# Altium schematic sheet P07_STM32MP1_DDR3_XTAL_RT.SchDoc(P07_STM32MP1_DDR3_XTAL_RT)
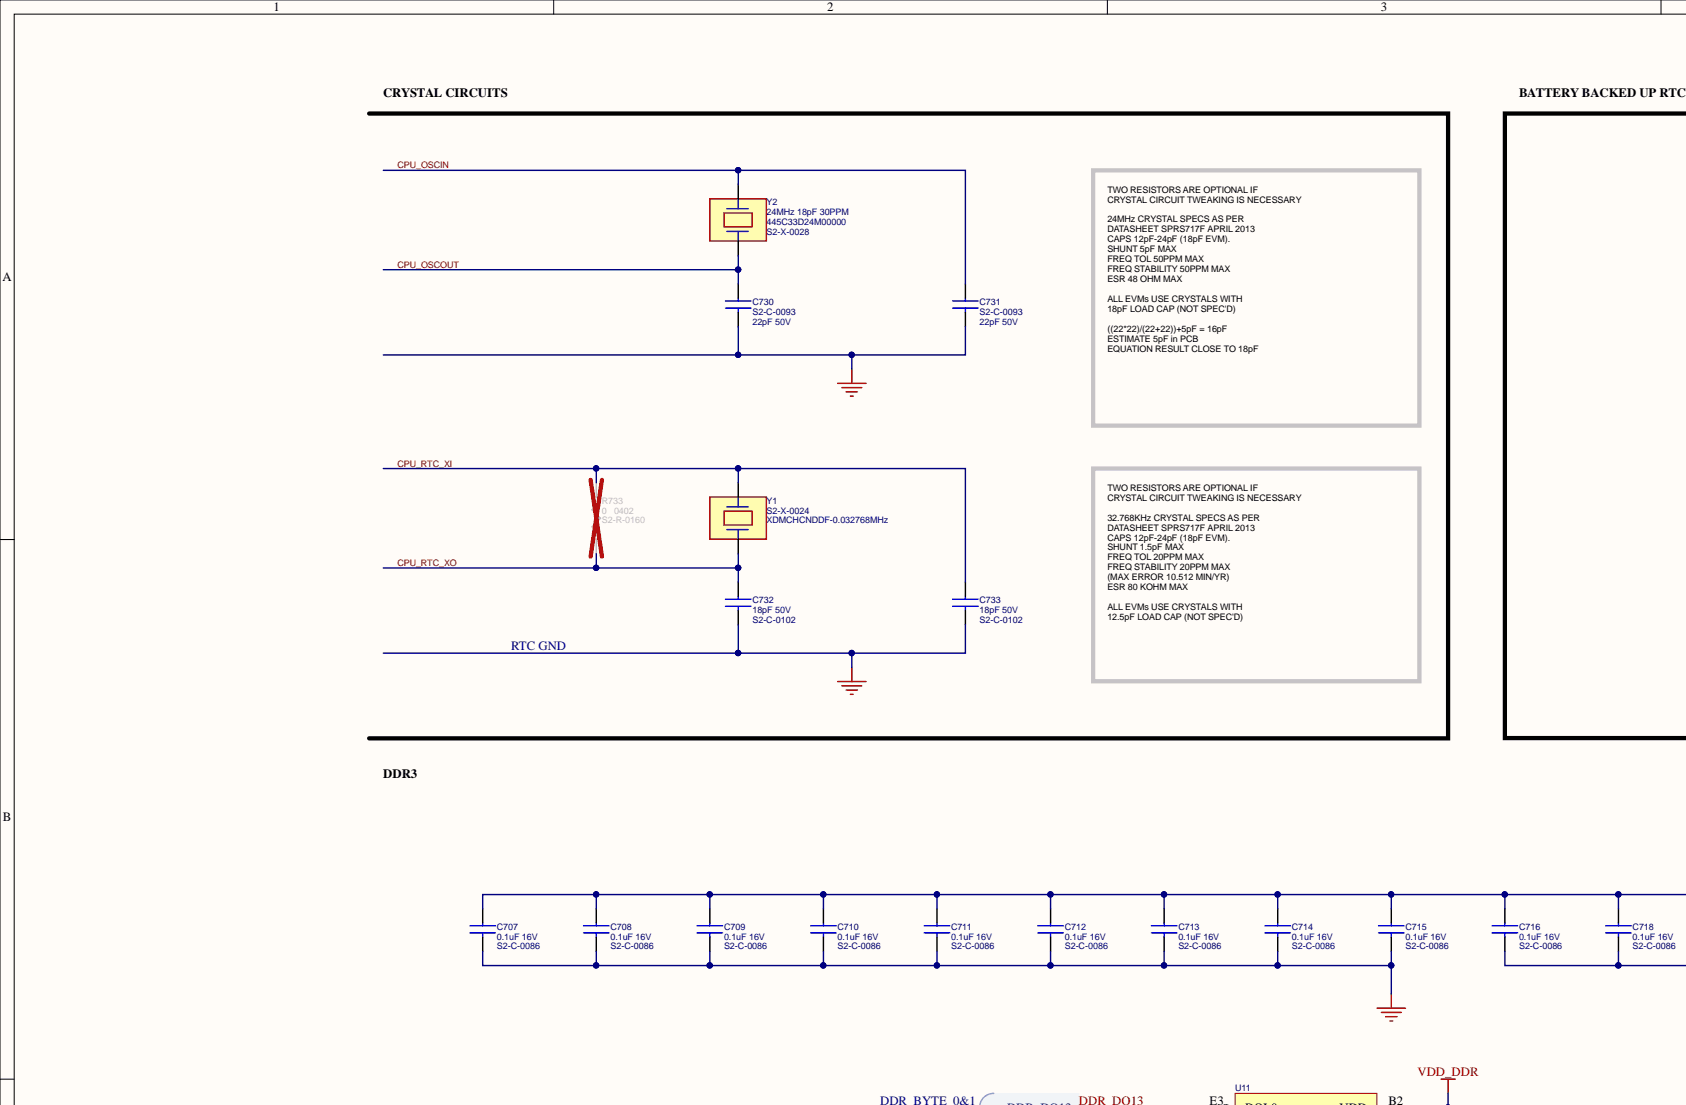
[diagram p0: P07_STM32MP1_DDR3_XTAL_RT.SchDoc, top left]
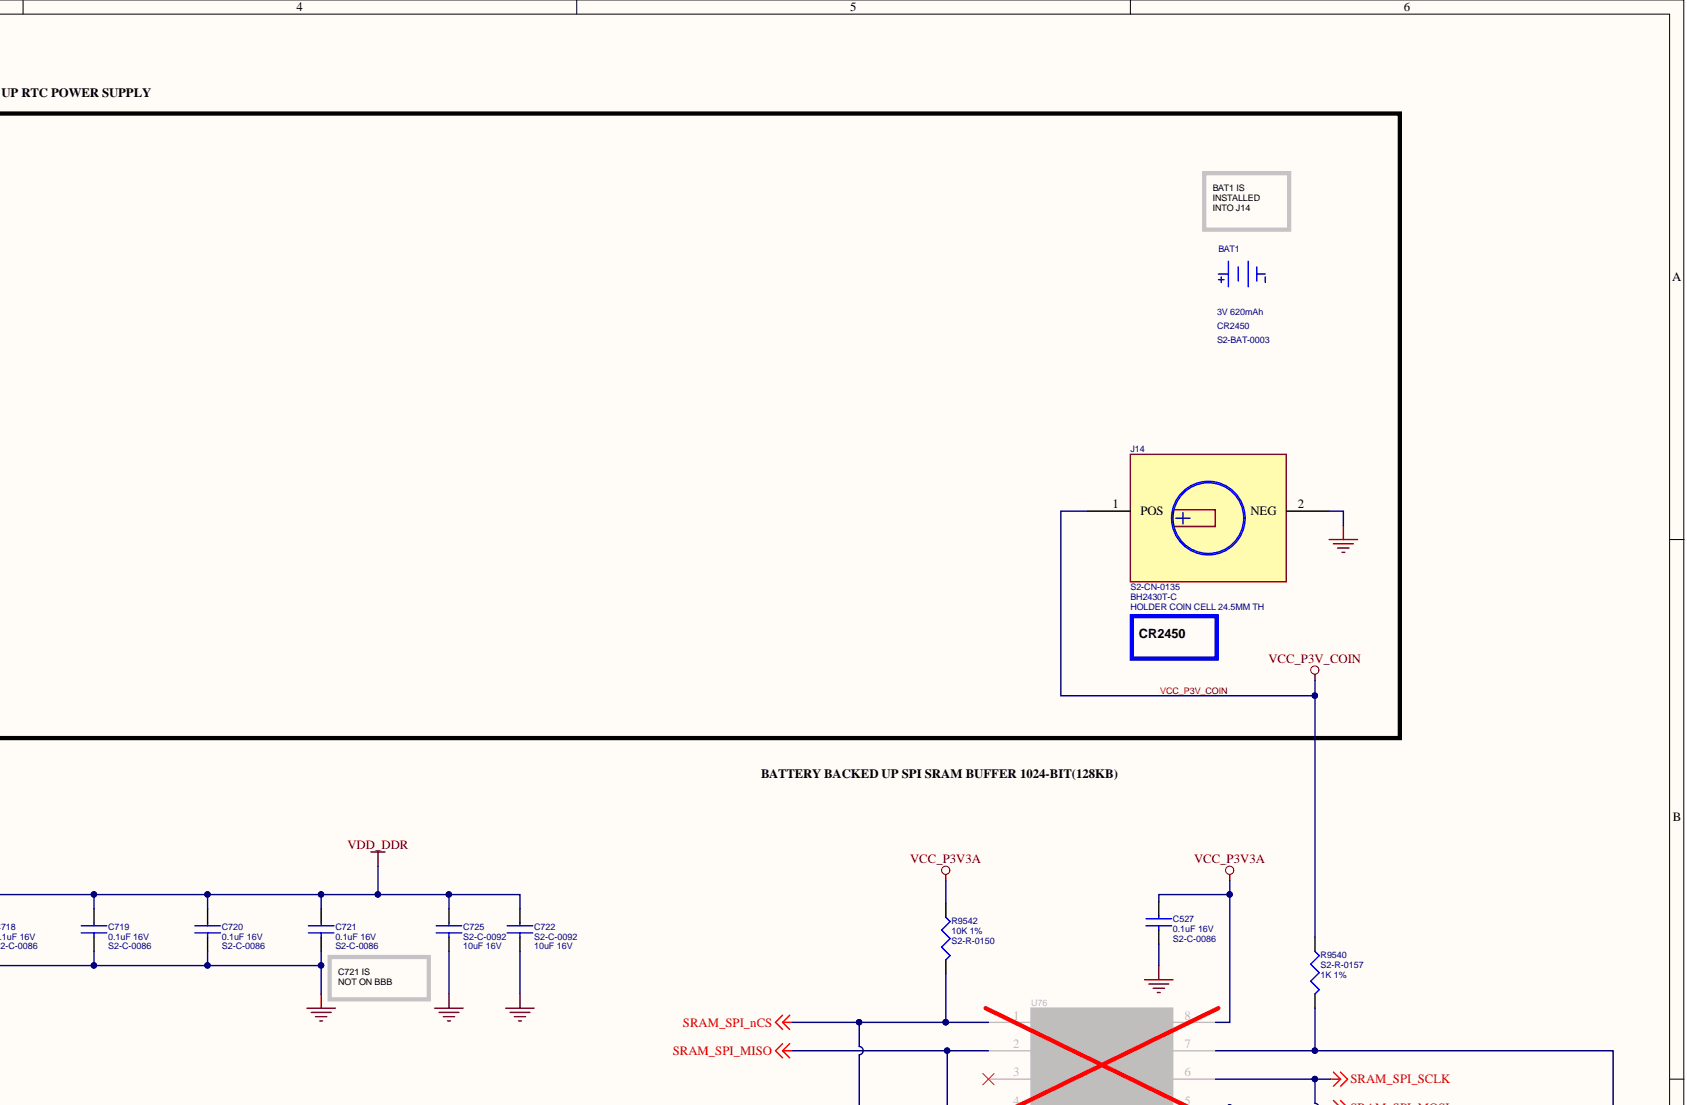
[diagram p1: P07_STM32MP1_DDR3_XTAL_RT.SchDoc, top right]
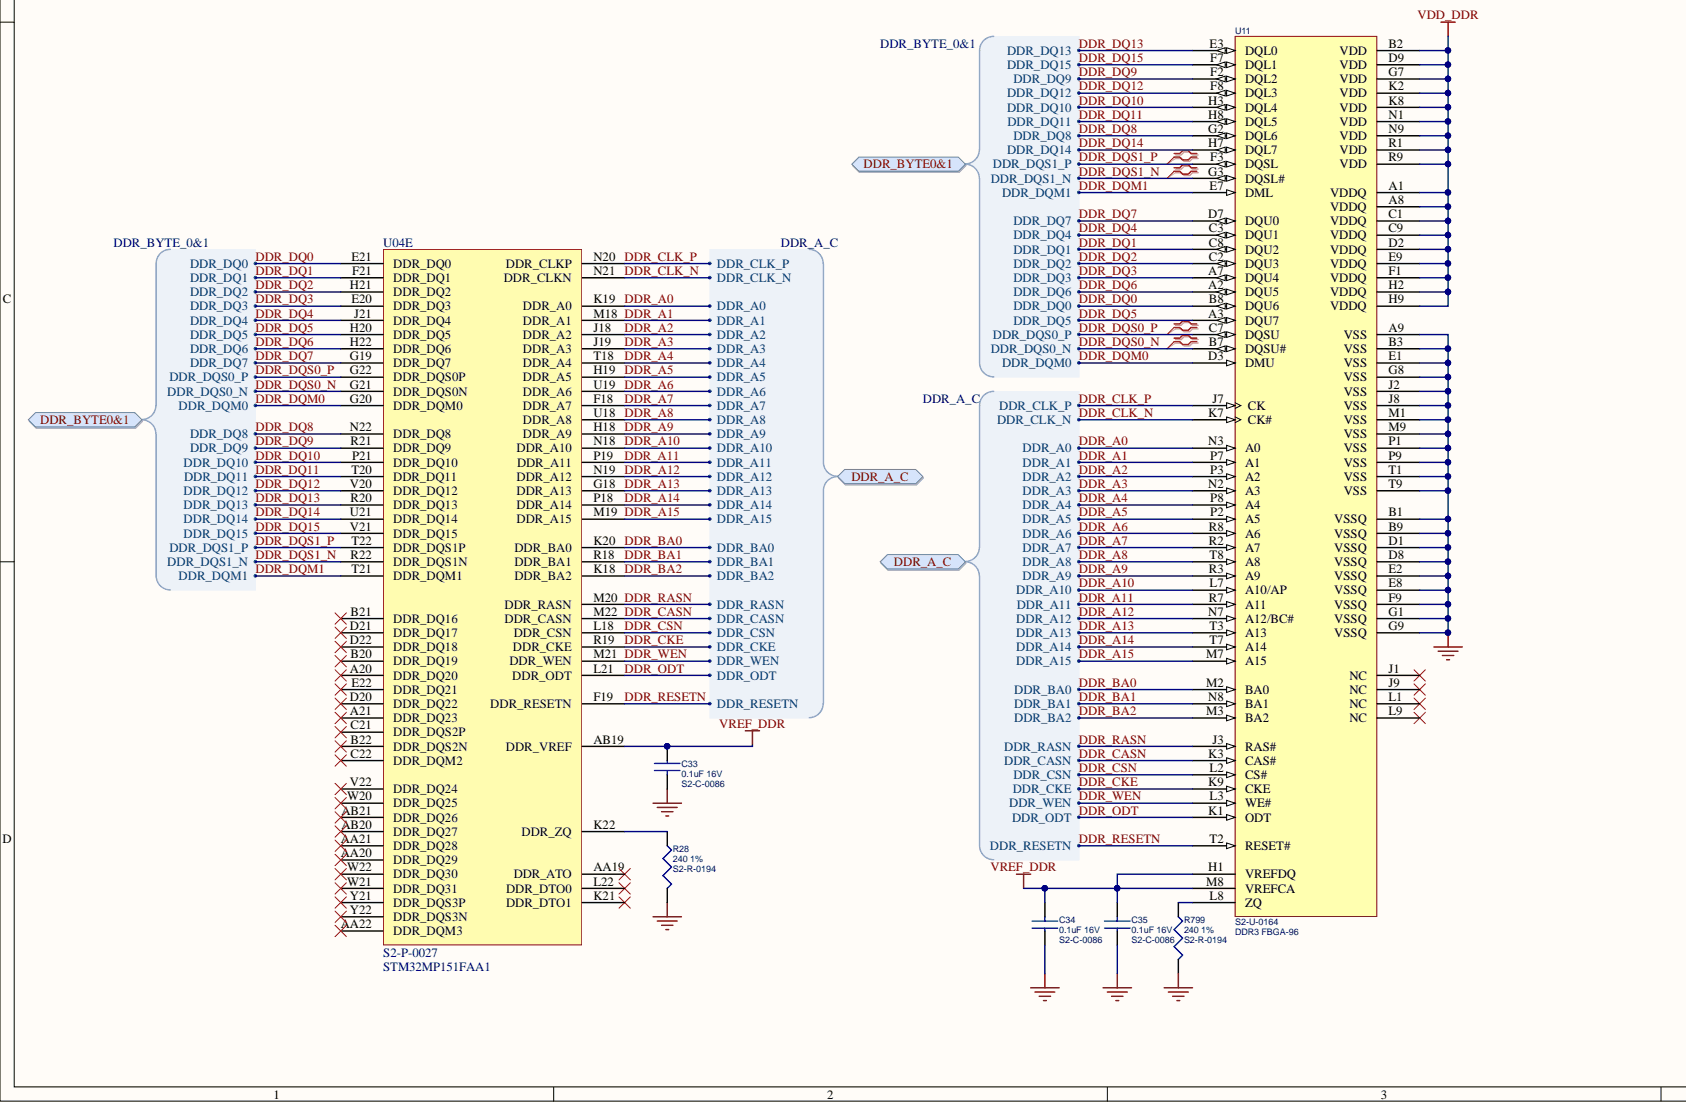
[diagram p2: P07_STM32MP1_DDR3_XTAL_RT.SchDoc, bottom left]
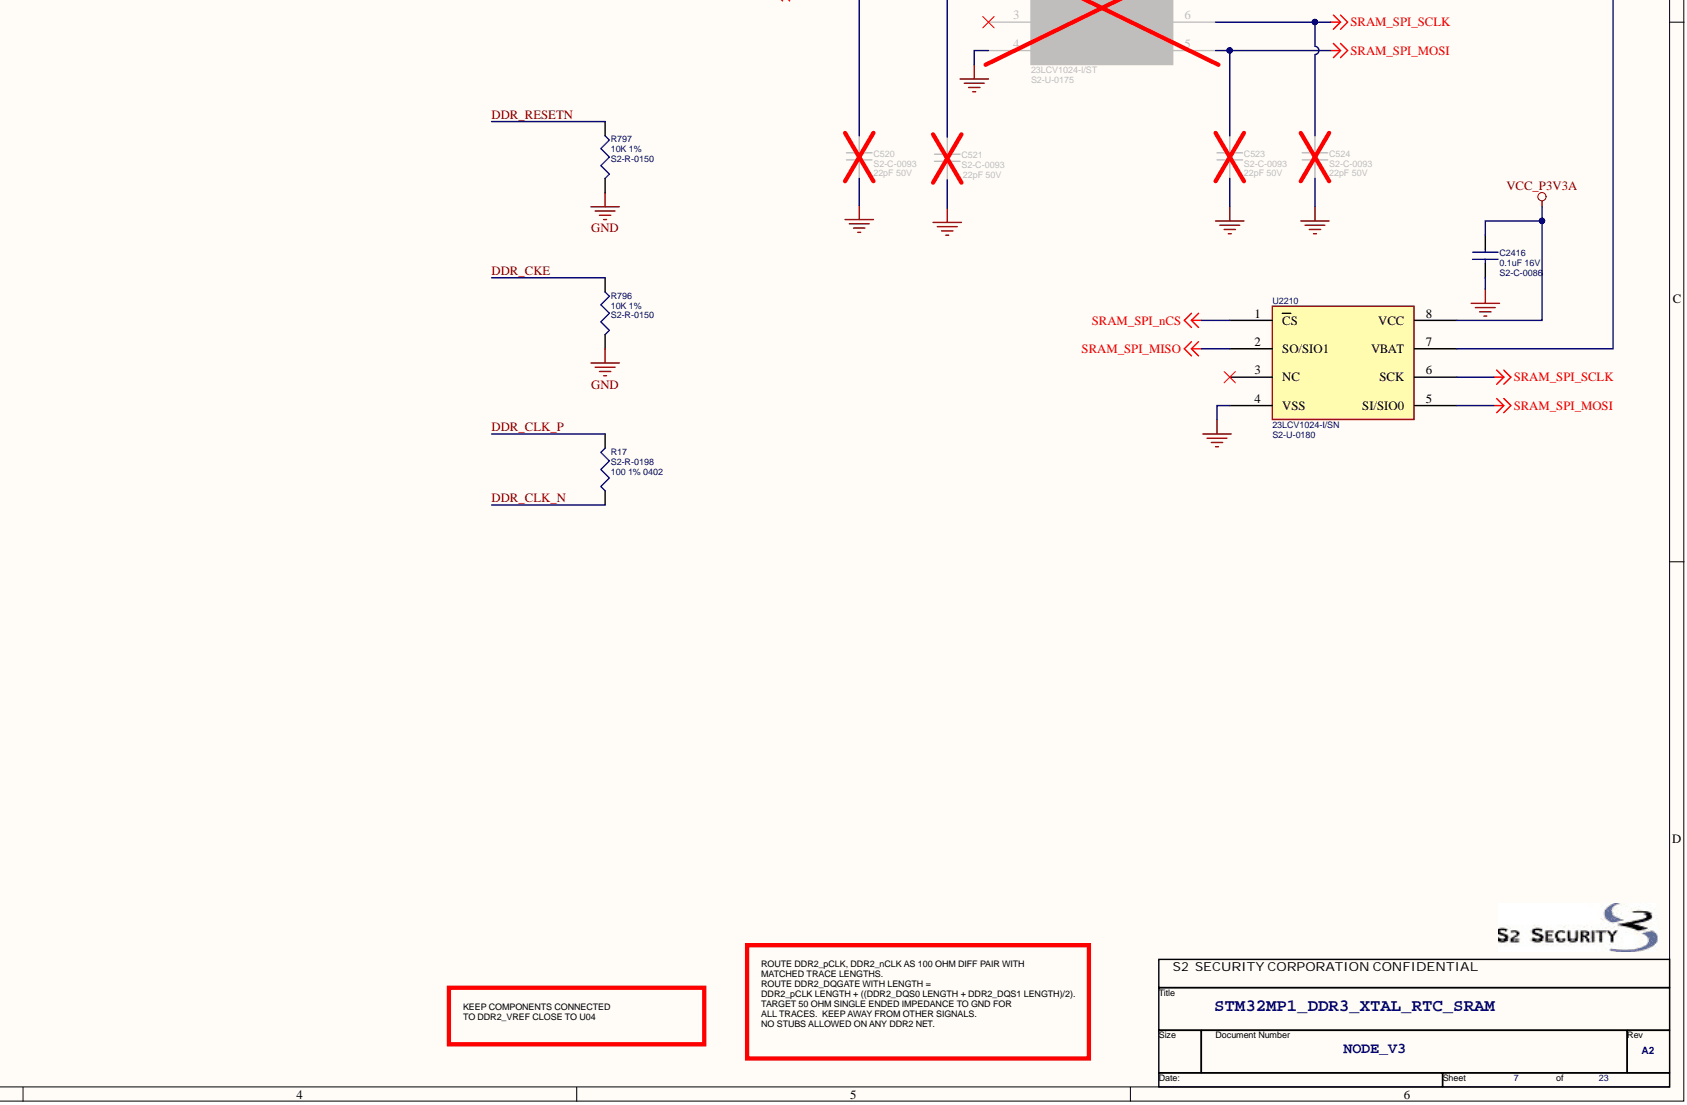
[diagram p3: P07_STM32MP1_DDR3_XTAL_RT.SchDoc, bottom right]

## Parts
BAT1  S2_PN: S2-BAT-0003  DESC: CR2450  ATTR: 3V 620mAh  [N/A]  (hidden comment: BAT_CR2450)
C33  S2_PN: S2-C-0086  DESC: 0.1uF 16V  [CAPC1005X55N]  (hidden comment: C_0U1_16V_0402)
C34  S2_PN: S2-C-0086  [CAPC1005X55N]  (hidden comment: C_0U1_16V_0402)
C35  S2_PN: S2-C-0086  DESC: 0.1uF 16V  [CAPC1005X55N]  (hidden comment: C_0U1_16V_0402)
C520  S2_PN: S2-C-0093  DESC: 22pF 50V  [CAPC1005X55N]  (hidden comment: C_22P_50V_0402_C0G)
C521  S2_PN: S2-C-0093  DESC: 22pF 50V  [CAPC1005X55N]  (hidden comment: C_22P_50V_0402_C0G)
C523  S2_PN: S2-C-0093  DESC: 22pF 50V  [CAPC1005X55N]  (hidden comment: C_22P_50V_0402_C0G)
C524  S2_PN: S2-C-0093  DESC: 22pF 50V  [CAPC1005X55N]  (hidden comment: C_22P_50V_0402_C0G)
C527  S2_PN: S2-C-0086  DESC: 0.1uF 16V  [CAPC1005X55N]  (hidden comment: C_0U1_16V_0402)
C707  S2_PN: S2-C-0086  DESC: 0.1uF 16V  [CAPC1005X55N]  (hidden comment: C_0U1_16V_0402)
C708  S2_PN: S2-C-0086  DESC: 0.1uF 16V  [CAPC1005X55N]  (hidden comment: C_0U1_16V_0402)
C709  S2_PN: S2-C-0086  DESC: 0.1uF 16V  [CAPC1005X55N]  (hidden comment: C_0U1_16V_0402)
C710  S2_PN: S2-C-0086  DESC: 0.1uF 16V  [CAPC1005X55N]  (hidden comment: C_0U1_16V_0402)
C711  S2_PN: S2-C-0086  DESC: 0.1uF 16V  [CAPC1005X55N]  (hidden comment: C_0U1_16V_0402)
C712  S2_PN: S2-C-0086  DESC: 0.1uF 16V  [CAPC1005X55N]  (hidden comment: C_0U1_16V_0402)
C713  S2_PN: S2-C-0086  DESC: 0.1uF 16V  [CAPC1005X55N]  (hidden comment: C_0U1_16V_0402)
C714  S2_PN: S2-C-0086  DESC: 0.1uF 16V  [CAPC1005X55N]  (hidden comment: C_0U1_16V_0402)
C715  S2_PN: S2-C-0086  DESC: 0.1uF 16V  [CAPC1005X55N]  (hidden comment: C_0U1_16V_0402)
C716  S2_PN: S2-C-0086  DESC: 0.1uF 16V  [CAPC1005X55N]  (hidden comment: C_0U1_16V_0402)
C718  S2_PN: S2-C-0086  DESC: 0.1uF 16V  [CAPC1005X55N]  (hidden comment: C_0U1_16V_0402)
C719  S2_PN: S2-C-0086  DESC: 0.1uF 16V  [CAPC1005X55N]  (hidden comment: C_0U1_16V_0402)
C720  S2_PN: S2-C-0086  DESC: 0.1uF 16V  [CAPC1005X55N]  (hidden comment: C_0U1_16V_0402)
C721  S2_PN: S2-C-0086  DESC: 0.1uF 16V  [CAPC1005X55N]  (hidden comment: C_0U1_16V_0402)
C722  S2_PN: S2-C-0092  DESC: 10uF 16V  [CAPC2012X145N]  (hidden comment: C_10U_16V_0805)
C725  S2_PN: S2-C-0092  [CAPC2012X145N]  (hidden comment: C_10U_16V_0805)
C730  S2_PN: S2-C-0093  DESC: 22pF 50V  [CAPC1005X55N]  (hidden comment: C_22P_50V_0402_C0G)
C731  S2_PN: S2-C-0093  DESC: 22pF 50V  [CAPC1005X55N]  (hidden comment: C_22P_50V_0402_C0G)
C732  S2_PN: S2-C-0102  DESC: 18pF 50V  [CAPC1005X55N]  (hidden comment: C_18P_50V_0402_C0G)
C733  S2_PN: S2-C-0102  DESC: 18pF 50V  [CAPC1005X55N]  (hidden comment: C_18P_50V_0402_C0G)
C2416  S2_PN: S2-C-0086  DESC: 0.1uF 16V  [CAPC1005X55N]  (hidden comment: C_0U1_16V_0402)
J14  S2_PN: S2-CN-0135  DESC: BH2430T-C  ATTR: HOLDER COIN CELL 24.5MM TH  [BAT_BH2430T-C]  (hidden comment: CN_BH2430T_FOR_CR2450__BH32T)
R17  S2_PN: S2-R-0198  DESC: 100 1% 0402  [RESC1005X40N]  (hidden comment: R_100_0402)
R28  S2_PN: S2-R-0194  DESC: 240 1%  [RESC1005X40N]  (hidden comment: R_240_0402)
R733  S2_PN: S2-R-0160  DESC: 0   0402  [RESC1005X40N]  (hidden comment: R_0_0402)
R796  S2_PN: S2-R-0150  DESC: 10K 1%  [RESC1005X40N]  (hidden comment: R_10K_0402)
R797  S2_PN: S2-R-0150  DESC: 10K 1%  [RESC1005X40N]  (hidden comment: R_10K_0402)
R799  S2_PN: S2-R-0194  DESC: 240 1%  [RESC1005X40N]  (hidden comment: R_240_0402)
R9540  S2_PN: S2-R-0157  DESC: 1K 1%  [RESC1005X40N]  (hidden comment: R_1K_0402)
R9542  S2_PN: S2-R-0150  DESC: 10K 1%  [RESC1005X40N]  (hidden comment: R_10K_0402)
U04  S2_PN: S2-P-0027  DESC: STM32MP151FAA1  [ICC_LFBGA448_18X18_STM32MP157BAA3_P080]  (hidden comment: U_STM32MP15xxAAx)  (part E)
U11  S2_PN: S2-U-0164  PKG: FBGA-96  DESC: DDR3 FBGA-96  [BGA96_9MMX13.5MM_.8MM]  (hidden comment: U_DDR3_FPGA-96)
U76  S2_PN: S2-U-0175  DESC: 23LCV1024-I/ST  [TSSOP-8]  (hidden comment: U_NVSRAM_SPI_TSSOP-8)
U2210  S2_PN: S2-U-0180  DESC: 23LCV1024-I/SN  [SOIC127P600X175-8N]  (hidden comment: U_NVSRAM_SPI_SOIC-8)
Y1  S2_PN: S2-X-0024  DESC: XDMCHCNDDF-0.032768MHz  [Crystal_XDMCHCNDDF]  (hidden comment: X_32M768_XTAL_XD)
Y2  S2_PN: S2-X-0028  DESC: 445C33D24M00000  [Crystal_445C33D24M00000]  (hidden comment: X_24MHZ_XTAL_2P_5X3MM2)

## Ports
DDR_A_C, DDR_A_C.DDR_A0, DDR_A_C.DDR_A1, DDR_A_C.DDR_A2, DDR_A_C.DDR_A3, DDR_A_C.DDR_A4, DDR_A_C.DDR_A5, DDR_A_C.DDR_A6, DDR_A_C.DDR_A7, DDR_A_C.DDR_A8, DDR_A_C.DDR_A9, DDR_A_C.DDR_A10, DDR_A_C.DDR_A11, DDR_A_C.DDR_A12, DDR_A_C.DDR_A13, DDR_A_C.DDR_A14, DDR_A_C.DDR_A15, DDR_A_C.DDR_BA0, DDR_A_C.DDR_BA1, DDR_A_C.DDR_BA2, DDR_A_C.DDR_CASN, DDR_A_C.DDR_CKE, DDR_A_C.DDR_CLK_N, DDR_A_C.DDR_CLK_P, DDR_A_C.DDR_CSN, DDR_A_C.DDR_ODT, DDR_A_C.DDR_RASN, DDR_A_C.DDR_RESETN, DDR_A_C.DDR_WEN, DDR_BYTE0&1, DDR_BYTE0&1.DDR_DQ0, DDR_BYTE0&1.DDR_DQ1, DDR_BYTE0&1.DDR_DQ2, DDR_BYTE0&1.DDR_DQ3, DDR_BYTE0&1.DDR_DQ4, DDR_BYTE0&1.DDR_DQ5, DDR_BYTE0&1.DDR_DQ6, DDR_BYTE0&1.DDR_DQ7, DDR_BYTE0&1.DDR_DQ8, DDR_BYTE0&1.DDR_DQ9, DDR_BYTE0&1.DDR_DQ10, DDR_BYTE0&1.DDR_DQ11, DDR_BYTE0&1.DDR_DQ12, DDR_BYTE0&1.DDR_DQ13, DDR_BYTE0&1.DDR_DQ14, DDR_BYTE0&1.DDR_DQ15, DDR_BYTE0&1.DDR_DQM0, DDR_BYTE0&1.DDR_DQM1, DDR_BYTE0&1.DDR_DQS0_N, DDR_BYTE0&1.DDR_DQS0_P, DDR_BYTE0&1.DDR_DQS1_N, DDR_BYTE0&1.DDR_DQS1_P

## Nets
GND: C33.2 C34.1 C35.1 C520.2 C521.2 C523.2 C524.2 C527.2 C707.2 C708.2 C709.2 C710.2 C711.2 C712.2 C713.2 C714.2 C715.2 C716.2 C718.2 C719.2 C720.2 C721.2 C722.2 C725.2 C730.2 C731.2 C732.2 C733.2 C2416.2 J14.2 R28.2 R796.2 R797.2 R799.2 U11.A9(VSS) U11.B1(VSSQ) U11.B3(VSS) U11.B9(VSSQ) U11.D1(VSSQ) U11.D8(VSSQ) U11.E1(VSS) U11.E2(VSSQ) U11.E8(VSSQ) U11.F9(VSSQ) U11.G1(VSSQ) U11.G8(VSS) U11.G9(VSSQ) U11.J2(VSS) U11.J8(VSS) U11.M1(VSS) U11.M9(VSS) U11.P1(VSS) U11.P9(VSS) U11.T1(VSS) U11.T9(VSS) U76.4(VSS) U2210.4(VSS)
SRAM_SPI_MISO: C521.1 U76.2(SO/SIO1) U2210.2(SO/SIO1)
SRAM_SPI_MOSI: C523.1 U76.5(SI/SIO0) U2210.5(SI/SIO0)
SRAM_SPI_nCS: C520.1 R9542.2 U76.1(CS) U2210.1(CS)
SRAM_SPI_SCLK: C524.1 U76.6(SCK) U2210.6(SCK)
VCC_P3V3A: C527.1 C2416.1 R9542.1 U76.8(VCC) U2210.8(VCC)
VDD_DDR: C707.1 C708.1 C709.1 C710.1 C711.1 C712.1 C713.1 C714.1 C715.1 C716.1 C718.1 C719.1 C720.1 C721.1 C722.1 C725.1 U11.A1(VDDQ) U11.A8(VDDQ) U11.B2(VDD) U11.C1(VDDQ) U11.C9(VDDQ) U11.D2(VDDQ) U11.D9(VDD) U11.E9(VDDQ) U11.F1(VDDQ) U11.G7(VDD) U11.H2(VDDQ) U11.H9(VDDQ) U11.K2(VDD) U11.K8(VDD) U11.N1(VDD) U11.N9(VDD) U11.R1(VDD) U11.R9(VDD)
VREF_DDR: C33.1 C34.2 C35.2 U04.AB19(DDR_VREF) U11.H1(VREFDQ) U11.M8(VREFCA)
CPU_OSCIN: C731.1 Y2.2
CPU_OSCOUT: C730.1 Y2.1
CPU_RTC_XI: C733.1 R733.1 Y1.2
CPU_RTC_XO: C732.1 R733.2 Y1.1
DDR_A0: U04.K19(DDR_A0) U11.N3(A0) ; port DDR_A_C
DDR_A1: U04.M18(DDR_A1) U11.P7(A1) ; port DDR_A_C
DDR_A2: U04.J18(DDR_A2) U11.P3(A2) ; port DDR_A_C
DDR_A3: U04.J19(DDR_A3) U11.N2(A3) ; port DDR_A_C
DDR_A4: U04.T18(DDR_A4) U11.P8(A4) ; port DDR_A_C
DDR_A5: U04.H19(DDR_A5) U11.P2(A5) ; port DDR_A_C
DDR_A6: U04.U19(DDR_A6) U11.R8(A6) ; port DDR_A_C
DDR_A7: U04.F18(DDR_A7) U11.R2(A7) ; port DDR_A_C
DDR_A8: U04.U18(DDR_A8) U11.T8(A8) ; port DDR_A_C
DDR_A9: U04.H18(DDR_A9) U11.R3(A9) ; port DDR_A_C
DDR_A10: U04.N18(DDR_A10) U11.L7(A10/AP) ; port DDR_A_C
DDR_A11: U04.P19(DDR_A11) U11.R7(A11) ; port DDR_A_C
DDR_A12: U04.N19(DDR_A12) U11.N7(A12/BC#) ; port DDR_A_C
DDR_A13: U04.G18(DDR_A13) U11.T3(A13) ; port DDR_A_C
DDR_A14: U04.P18(DDR_A14) U11.T7(A14) ; port DDR_A_C
DDR_A15: U04.M19(DDR_A15) U11.M7(A15) ; port DDR_A_C
DDR_BA0: U04.K20(DDR_BA0) U11.M2(BA0) ; port DDR_A_C
DDR_BA1: U04.R18(DDR_BA1) U11.N8(BA1) ; port DDR_A_C
DDR_BA2: U04.K18(DDR_BA2) U11.M3(BA2) ; port DDR_A_C
DDR_CASN: U04.M22(DDR_CASN) U11.K3(CAS#) ; port DDR_A_C
DDR_CKE: R796.1 U04.R19(DDR_CKE) U11.K9(CKE) ; port DDR_A_C
DDR_CLK_N: R17.1 U04.N21(DDR_CLKN) U11.K7(CK#) ; port DDR_A_C
DDR_CLK_P: R17.2 U04.N20(DDR_CLKP) U11.J7(CK) ; port DDR_A_C
DDR_CSN: U04.L18(DDR_CSN) U11.L2(CS#) ; port DDR_A_C
DDR_DQ0: U04.E21(DDR_DQ0) U11.B8(DQU6) ; port DDR_BYTE0&1
DDR_DQ1: U04.F21(DDR_DQ1) U11.C8(DQU2) ; port DDR_BYTE0&1
DDR_DQ2: U04.H21(DDR_DQ2) U11.C2(DQU3) ; port DDR_BYTE0&1
DDR_DQ3: U04.E20(DDR_DQ3) U11.A7(DQU4) ; port DDR_BYTE0&1
DDR_DQ4: U04.J21(DDR_DQ4) U11.C3(DQU1) ; port DDR_BYTE0&1
DDR_DQ5: U04.H20(DDR_DQ5) U11.A3(DQU7) ; port DDR_BYTE0&1
DDR_DQ6: U04.H22(DDR_DQ6) U11.A2(DQU5) ; port DDR_BYTE0&1
DDR_DQ7: U04.G19(DDR_DQ7) U11.D7(DQU0) ; port DDR_BYTE0&1
DDR_DQ8: U04.N22(DDR_DQ8) U11.G2(DQL6) ; port DDR_BYTE0&1
DDR_DQ9: U04.R21(DDR_DQ9) U11.F2(DQL2) ; port DDR_BYTE0&1
DDR_DQ10: U04.P21(DDR_DQ10) U11.H3(DQL4) ; port DDR_BYTE0&1
DDR_DQ11: U04.T20(DDR_DQ11) U11.H8(DQL5) ; port DDR_BYTE0&1
DDR_DQ12: U04.V20(DDR_DQ12) U11.F8(DQL3) ; port DDR_BYTE0&1
DDR_DQ13: U04.R20(DDR_DQ13) U11.E3(DQL0) ; port DDR_BYTE0&1
DDR_DQ14: U04.U21(DDR_DQ14) U11.H7(DQL7) ; port DDR_BYTE0&1
DDR_DQ15: U04.V21(DDR_DQ15) U11.F7(DQL1) ; port DDR_BYTE0&1
DDR_DQM0: U04.G20(DDR_DQM0) U11.D3(DMU) ; port DDR_BYTE0&1
DDR_DQM1: U04.T21(DDR_DQM1) U11.E7(DML) ; port DDR_BYTE0&1
DDR_DQS0_N: U04.G21(DDR_DQS0N) U11.B7(DQSU#) ; port DDR_BYTE0&1
DDR_DQS0_P: U04.G22(DDR_DQS0P) U11.C7(DQSU) ; port DDR_BYTE0&1
DDR_DQS1_N: U04.R22(DDR_DQS1N) U11.G3(DQSL#) ; port DDR_BYTE0&1
DDR_DQS1_P: U04.T22(DDR_DQS1P) U11.F3(DQSL) ; port DDR_BYTE0&1
DDR_ODT: U04.L21(DDR_ODT) U11.K1(ODT) ; port DDR_A_C
DDR_RASN: U04.M20(DDR_RASN) U11.J3(RAS#) ; port DDR_A_C
DDR_RESETN: R797.1 U04.F19(DDR_RESETN) U11.T2(RESET#) ; port DDR_A_C
DDR_WEN: U04.M21(DDR_WEN) U11.L3(WE#) ; port DDR_A_C
VCC_P3V_COIN: J14.1 R9540.1
NetR28_1: R28.1 U04.K22(DDR_ZQ)
NetR799_1: R799.1 U11.L8(ZQ)
NetR9540_2: R9540.2 U76.7(VBAT) U2210.7(VBAT)

Not connected: U04 A20(DDR_DQ20) A21(DDR_DQ23) AA19(DDR_ATO) AA20(DDR_DQ29) AA21(DDR_DQ28) AA22(DDR_DQM3) AB20(DDR_DQ27) AB21(DDR_DQ26) B20(DDR_DQ19) B21(DDR_DQ16) B22(DDR_DQS2N) C21(DDR_DQS2P) C22(DDR_DQM2) D20(DDR_DQ22) D21(DDR_DQ17) D22(DDR_DQ18) E22(DDR_DQ21) K21(DDR_DTO1) L22(DDR_DTO0) V22(DDR_DQ24) W20(DDR_DQ25) W21(DDR_DQ31) W22(DDR_DQ30) Y21(DDR_DQS3P) Y22(DDR_DQS3N); U11 J1(NC) J9(NC) L1(NC) L9(NC); U76 3(NC); U2210 3(NC)

## Library data of the parts
BAT1 = BAT_CR2450: PKG: COIN_24MM5x5MM; Component Kind: Standard
C33 C35 C527 C707-C716 C718-C721 C2416 = C_0U1_16V_0402: PKG: CC0402IPC; ATTR: X7R 10%; Library Name: NODE_V2_M1.SCHLIB; Component Kind: Standard
C34 = C_0U1_16V_0402: PKG: CC0402IPC; DESC: 0.1uF 16V; ATTR: X7R 10%; Library Name: NODE_V2_M1.SCHLIB; Component Kind: Standard
C520 C521 C523 C524 C730 C731 = C_22P_50V_0402_C0G: PKG: CC0402IPC; ATTR: C0G 5%; Library Name: NODE_V2_M1.SCHLIB; Component Kind: Standard
C722 = C_10U_16V_0805: PKG: CC0805IPC; ATTR: X5R 10%; Library Name: NODE_V2_M1.SCHLIB; Component Kind: Standard
C725 = C_10U_16V_0805: PKG: CC0805IPC; DESC: 10uF 16V; ATTR: X5R 10%; Library Name: NODE_V2_M1.SCHLIB; Component Kind: Standard
C732 C733 = C_18P_50V_0402_C0G: PKG: CC0402IPC; ATTR: C0G 5%; Library Name: NODE_V2_M1.SCHLIB; Component Kind: Standard
J14 = CN_BH2430T_FOR_CR2450__BH32T: PKG: BH2430T_BH32T; ATTR2: BH32T-C CR2032 ALSO FITS; Library Name: NODE_V2_M1.SCHLIB; Component Kind: Standard
R17 = R_100_0402: PKG: CC0402IPC; ATTR: 1/16W; Library Name: NODE_V2_M1.SCHLIB; Component Kind: Standard
R28 R799 = R_240_0402: PKG: CC0402IPC; ATTR: 1/16W; Library Name: NODE_V2_M1.SCHLIB; Component Kind: Standard
R733 = R_0_0402: PKG: CC0402IPC; ATTR: 1/16W  50mohm MAX; Library Name: NODE_V2_M1.SCHLIB; Component Kind: Standard
R796 R797 R9542 = R_10K_0402: PKG: CC0402IPC; ATTR: 1/16W; Library Name: NODE_V2_M1.SCHLIB; Component Kind: Standard
R9540 = R_1K_0402: PKG: CC0402IPC; ATTR: 1/16W; Library Name: NODE_V2_M1.SCHLIB; DNP: DNP; Component Kind: Standard
U04 = (no symbol name): MPU LFBGA18x18; ATTR: ARM Cortex-A7 Microprocessor IC STM32MP1 1 Core, 32-Bit 209MHz, 800MHz 448-LFBGA (18x18); PKG: LFBGA18x18; Library Name: NODE_V2_M1.SCHLIB; Component Kind: Standard
U11 = U_DDR3_FPGA-96: ATTR: MEMORY DDR3 SDRAM x16 FBGA-96; Library Name: NODE_V2_M1.SCHLIB; Component Kind: Standard
U76 = U_NVSRAM_SPI_TSSOP-8: ATTR: IC NVSRAM SPI 1MBIT 20MHZ 8TSSOP; PKG: TSSOP-8; Library Name: NODE_V2_M1.SCHLIB; Component Kind: Standard
U2210 = U_NVSRAM_SPI_SOIC-8: PKG: SOIC-8; ATTR: IC NVSRAM SPI 1MBIT 20MHZ 8SOIC; Library Name: NODE_V2_M1.SCHLIB; Component Kind: Standard
Y1 = X_32M768_XTAL_XD: ATTR: CRYSTAL TUNING FORK  32.768KHZ 12.5PF SMD; PKG: XTAL_XD; Library Name: NODE_V2_M1.SCHLIB; Component Kind: Standard
Y2 = X_24MHZ_XTAL_2P_5X3MM2: ATTR2: 24MHz 18pF 30PPM; ATTR: CRYSTAL 24MHZ 18PF 30PPM 30PPM 40ESR 5x3.2MM; PKG: XTAL_2P_5X3MM2; Library Name: NODE_V2_M1.SCHLIB; Component Kind: Standard
